# Altium schematic sheet Sheet1.SchDoc
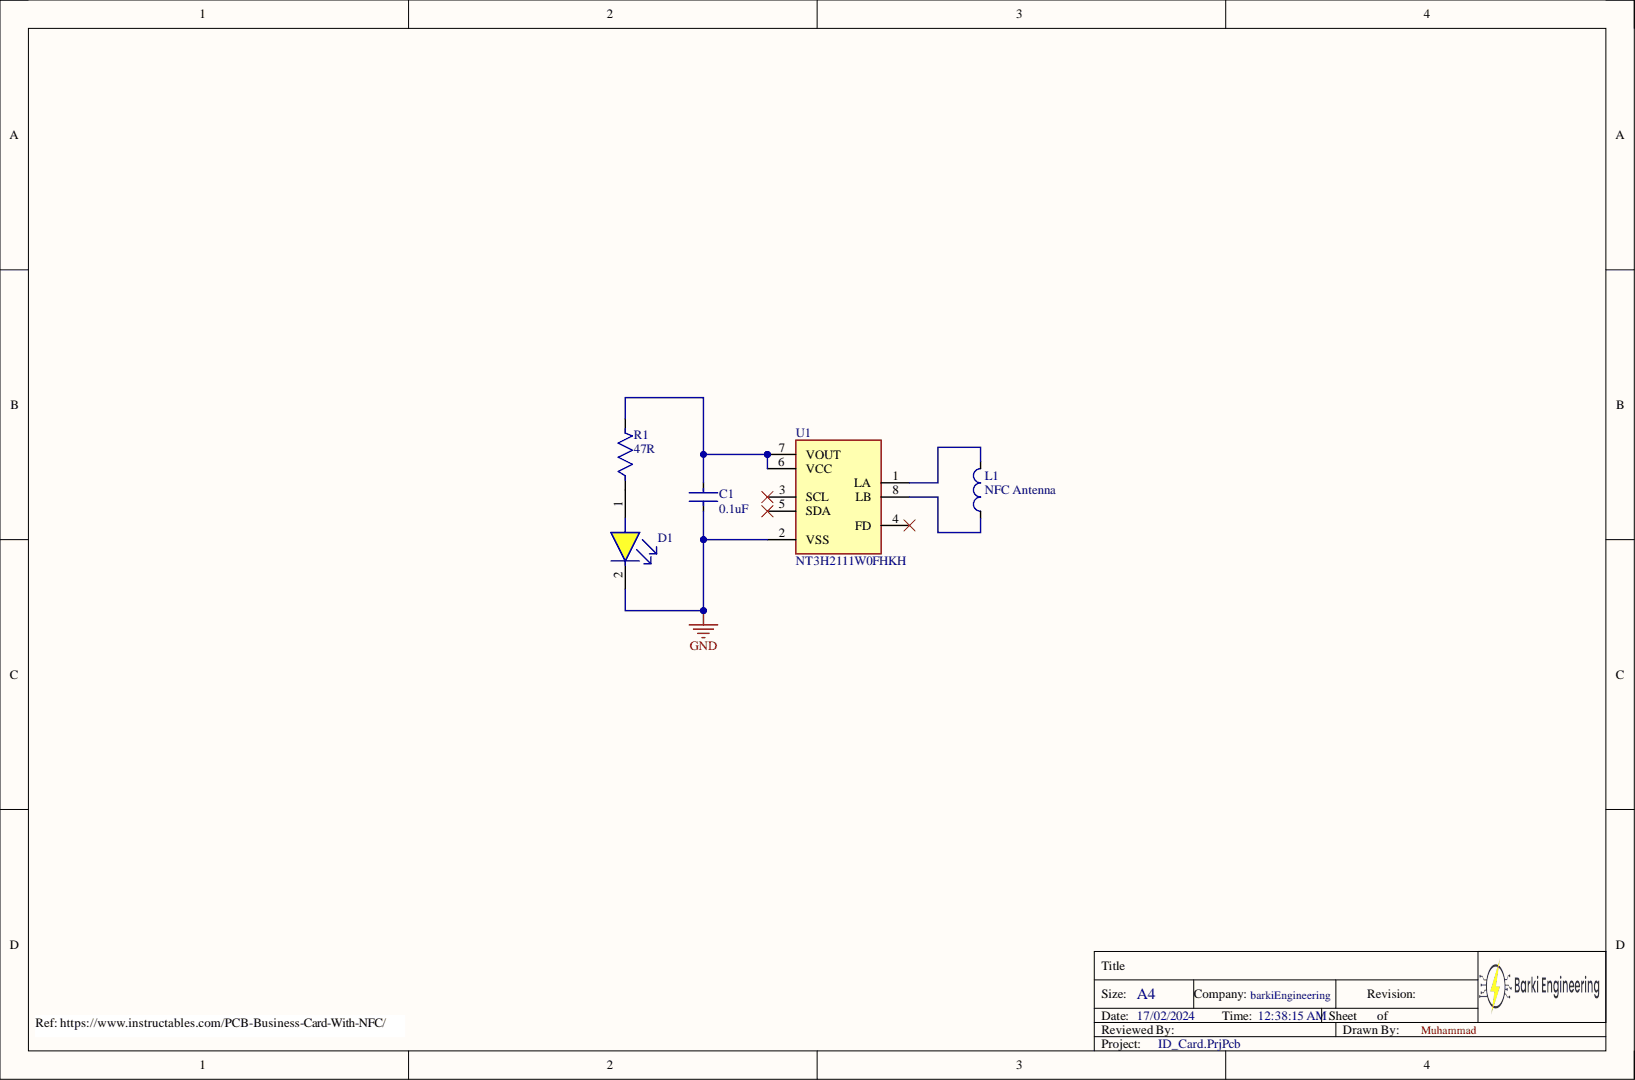
[diagram p0: Sheet1.SchDoc]

## Parts
C1  0.1uF  [C_0603]
D1  Yellow  [LED_0603]  (value not drawn)
L1  NFC Antenna  [25X48MM_NFC_ANTENNA]  (no BOM)
R1  47R  [R_0603]
U1  NT3H2111W0FHKH  [XFQFN-8]

## Nets
GND: C1.1 D1.2 U1.2(VSS)
NetC1_2: C1.2 R1.2 U1.6(VCC) U1.7(VOUT)
NetD1_1: D1.1 R1.1
NetL1_1: L1.1 U1.8(LB)
NetL1_2: L1.2 U1.1(LA)

Not connected: U1 3(SCL) 4(FD) 5(SDA)

## Library data of the parts
C1 = Cap 0.1uF 50V 10% X7R 0603: Ceramic Capacitor 0.1uF 10% X7R 50V 0603 T/R; Manufacturer: Samsung; Manufacturer Part Number: CL10B104KB8WPND; Category: Ceramic Capacitors; Component Kind: Standard; Library Name: OP_Library.SchLib; Pricing 1: 1=0.10000, 10=0.03400, 50=0.03400, 100=0.02600, 1000=0.01500, 10000=0.01100 (USD); RoHS: Yes; RoHS Compliant: Yes; Stock 1: 31698; Supplier 1: Mouser; Supplier Part Number 1: 187-CL10B104KB8WPND
D1 = LED Yellow 2V 20mA 0603: APT1608SYCK Yellow LED; 590 nm 1608 (0603) Clear; Rectangle Lens SMD package; Manufacturer: Kingbright; Dominant Wavelength: 590nm; Forward Current: 20mA; Forward Voltage: 2V; Lens Style: Clear,Flat; Luminous Intensity: 150mcd; Number of LEDs: 1; Viewing Angle: 120°; Case Code (Imperial): 0603; Case Code (Metric): 1608; Case/Package: 0603; Category: LEDs; Color: Yellow; Component Kind: Standard; ComponentLink1Description: https://datasheet.ciiva.com/2113/apt1608syck-2113824.pdf?src-supplier=Digi-Key; ComponentLink1URL: https://datasheet.ciiva.com/2113/apt1608syck-2113824.pdf?src-supplier=Digi-Key; ComponentLink2Description: https://datasheet.ciiva.com/4688/apt1608syck-35136-4688900.pdf?src-supplier=Mouser; ComponentLink2URL: https://datasheet.ciiva.com/4688/apt1608syck-35136-4688900.pdf?src-supplier=Mouser; ComponentLink3Description: https://datasheet.ciiva.com/2113/apt1608syck-2113825.pdf?src-supplier=Digi-Key; ComponentLink3URL: https://datasheet.ciiva.com/2113/apt1608syck-2113825.pdf?src-supplier=Digi-Key; ComponentLink4Description: https://datasheet.ciiva.com/2113/apt1608syck-2113826.pdf?src-supplier=Digi-Key; ComponentLink4URL: https://datasheet.ciiva.com/2113/apt1608syck-2113826.pdf?src-supplier=Digi-Key; ComponentLink5Description: https://datasheet.ciiva.com/14603/70062842-14603786.pdf?src-supplier=Allied+Electronics; ComponentLink5URL: https://datasheet.ciiva.com/14603/70062842-14603786.pdf?src-supplier=Allied+Electronics; Height: 0.75mm; Illumination Color: Yellow; Lead Free: Lead Free; Length: 1.6mm; Lens Color: Clear; Library Name: OP_Library.SchLib; Manufacturer Part Number: APT1608SYCK; Max Operating Temperature: 85°C; Min Operating Temperature: -40°C; Mounting Technology: Surface Mount; Package Quantity: 2000; Packaging: Tape and Reel; Pins: 2; Pricing 1: 1=0.29000, 10=0.22800, 100=0.10230, 500=0.09240, 1000=0.07590 (USD); RoHS: Yes; RoHS Compliant: Yes; Series: APT; Stock 1: 209827; Supplier 1: Digi-Key; Supplier Part Number 1: 754-1124-1-ND; Test Current: 20mA; Wavelength: 590nm; Width: 0.8mm
L1 = Antenna NFC 25X48MM: Antenna for NFC; Library Name: OP_Library.SchLib
R1 = Res 47R 1% 1/5W 0603: YAGEO (PHYCOMP)         RC0603FR-7W47RL             SMD Chip Resistor, Thick Film, 47 ohm, 50 V, 0603 [1608 Metric], 100 mW,  1%, RC Series; Power Rating: 100mW; Temperature Coefficient: 100ppm/°C; Voltage Rating: 50V; Case Code (Imperial): 0603; Case Code (Metric): 1608; Case/Package: 0603; Category: Chip SMD Resistors; Component Kind: Standard; ComponentLink1Description: http://www.farnell.com/datasheets/1675737.pdf?src-supplier=Farnell; ComponentLink1URL: http://www.farnell.com/datasheets/1675737.pdf?src-supplier=Farnell; ComponentLink2Description: http://www.farnell.com/datasheets/1675737.pdf?src-supplier=Element14; ComponentLink2URL: http://www.farnell.com/datasheets/1675737.pdf?src-supplier=Element14; Library Name: OP_Library.SchLib; Manufacturer: Yageo; Manufacturer Part Number: RC0603FR-7W47RL; Number of Pins: 2; Packaging: Cut Tape; Pricing 1: 1=0.10000, 10=0.03700, 50=0.03700, 100=0.01500, 1000=0.00700, 10000=0.00400 (USD); Resistance: 47R; Resistance Tolerance: 1%; Resistor Type: Thick Film; RoHS: Yes; RoHS Compliant: Yes; Series: RC0603; Stock 1: 5673; Supplier 1: Mouser; Supplier Part Number 1: 603-RC0603FR-7W47RL; Tolerance: 1%; Voltage Rating (DC): 50V
U1 = IC RFID Transponder 13.56MHz XQFN-8: NFC/RFID Tag and Transponder IC 13560kHz 1KByte Automotive 8-Pin XQFN EP T/R; Manufacturer: NXP Semiconductors; Interface: I2C; Category: Integrated Circuits (ICs); Component Kind: Standard; ComponentLink1Description: http://www.farnell.com/datasheets/2148700.pdf?src-supplier=Farnell; ComponentLink1URL: http://www.farnell.com/datasheets/2148700.pdf?src-supplier=Farnell; ComponentLink2Description: http://www.mouser.com/ds/2/302/NT3H2111_2211-888244.pdf?src-supplier=Mouser; ComponentLink2URL: http://www.mouser.com/ds/2/302/NT3H2111_2211-888244.pdf?src-supplier=Mouser; ComponentLink3Description: http://www.farnell.com/datasheets/2148700.pdf?src-supplier=Newark; ComponentLink3URL: http://www.farnell.com/datasheets/2148700.pdf?src-supplier=Newark; ComponentLink4Description: https://www.nxp.com/docs/en/data-sheet/NT3H2111_2211.pdf?src-supplier=Digikey; ComponentLink4URL: https://www.nxp.com/docs/en/data-sheet/NT3H2111_2211.pdf?src-supplier=Digikey; ComponentLink5Description: http://cache.nxp.com/documents/data_sheet/NT3H2111_2211.pdf?src-supplier=Digikey; ComponentLink5URL: http://cache.nxp.com/documents/data_sheet/NT3H2111_2211.pdf?src-supplier=Digikey; ComponentLink6Description: https://datasheet.octopart.com/NT3H2111W0FHKH-NXP-Semiconductors-datasheet-62111411.pdf?src-supplier=Newark; ComponentLink6URL: https://datasheet.octopart.com/NT3H2111W0FHKH-NXP-Semiconductors-datasheet-62111411.pdf?src-supplier=Newark; Frequency: 13.56MHz; Lead Free: Lead Free; Library Name: OP_Library.SchLib; Manufacturer Part Number: NT3H2111W0FHKH; Max Operating Temperature: 105°C; Min Operating Temperature: -40°C; Packaging: Cut Tape; Pricing: 1=1.31, 10=1.09, 50=1.09, 100=0.833, 1000=0.581, 10000=0.493 (USD); RoHS: Yes; RoHS Compliant: Yes; Series: -; Stock: 20122; Supplier: Mouser; Supplier Part Number: 771-NT3H2111W0FHKH
